# Altium schematic sheet Log and Anti-Log Converters 2.SchDoc
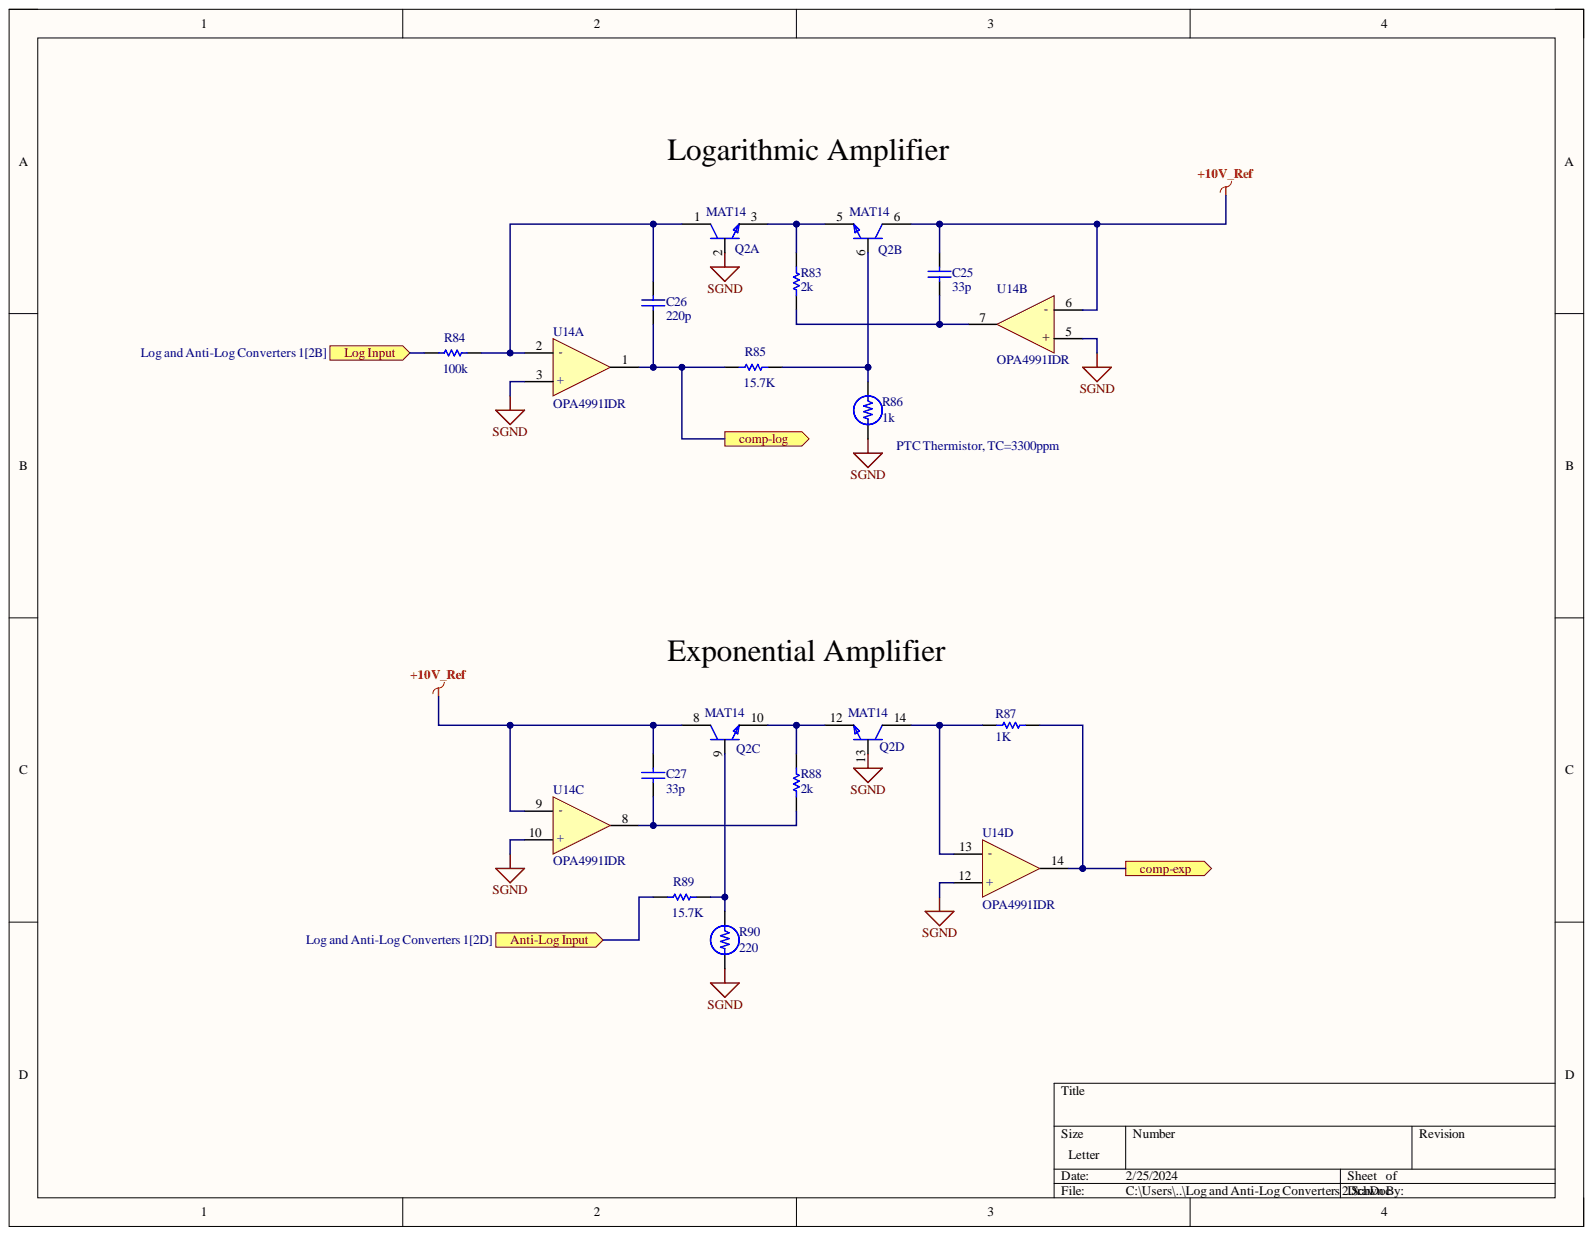
[diagram p0: Log and Anti-Log Converters 2.SchDoc]

## Parts
C25  value 33p  (hidden comment: Capacitor)
C26  value 220p  (hidden comment: Capacitor)
C27  value 33p  (hidden comment: Capacitor)
Q2  MAT14  [FP-R-14-IPC_B]  (parts A,B,C,D)
R83  value 2k  (hidden comment: Resistor)
R84  value 100k  (hidden comment: Resistor)
R85  value 15.7K  (hidden comment: Resistor)
R86  1k
R87  value 1K  (hidden comment: Resistor)
R88  value 2k  (hidden comment: Resistor)
R89  value 15.7K  (hidden comment: Resistor)
R90  220
U14  OPA4991IDR  [FP-D0014A-IPC_B]  (parts A,B,C,D)

## Ports
Anti-Log Input, comp-exp, comp-log, Log Input

## Nets
+10V_Ref: C25.2 C27.2 Q2.6 Q2.8 U14.6(-) U14.9(-)
SGND: Q2.2 Q2.13 R86.1 R90.1 U14.3(+) U14.5(+) U14.10(+) U14.12(+)
Anti-Log Input: R89.2 ; port Anti-Log Input
comp-exp: R87.2 U14.14 ; port comp-exp
comp-log: C26.1 R85.2 U14.1 ; port comp-log
Log Input: R84.2 ; port Log Input
NetC25_1: C25.1 R83.1 U14.7
NetC26_2: C26.2 Q2.1 R84.1 U14.2(-)
NetC27_1: C27.1 R88.1 U14.8
NetQ2_3: Q2.3 Q2.5 R83.2
NetQ2_6: Q2.6 R85.1 R86.2
NetQ2_9: Q2.9 R89.1 R90.2
NetQ2_10: Q2.10 Q2.12 R88.2
NetQ2_14: Q2.14 R87.1 U14.13(-)

## Library data of the parts
C25-C27 = Capacitor: SimulationGenericComponent: True; Component Kind: Standard; Description: Capacitor; Library Name: Simulation Generic Components.IntLib; Simulation: C_Capacitor_Default
Q2 = MAT14ARZ: TRANS 4NPN 40V 0.03A 14SO; Collector Emitter Saturation Voltage: 60mV; Frequency: 300MHz; Max Collector Current: 30mA; Max Frequency: 1kHz; Number of Elements: 4; Polarity: NPN; Case/Package: SOIC; Collector Base Voltage (VCBO): 40V; Collector Emitter Breakdown Voltage: 40V; Collector Emitter Voltage (VCEO): 40V; Collector-emitter Voltage-Max: 60mV; Component Kind: Standard; Height: 1.5mm; Lead Free: Contains Lead; Length: 8.75mm; Max Operating Temperature: 85°C; Min Operating Temperature: -40°C; Mount: Surface Mount; Number of Pins: 14; Package Quantity: 56; Packaging: Rail/Tube; Radiation Hardening: No; REACH SVHC: No SVHC; RoHS Compliant: Yes; Series: MAT14; Transition Frequency: 300MHz; Width: 4mm; Library Name: DRC.SchLib
R83-R85 R87-R89 = Resistor: SimulationGenericComponent: True; TC1: 0; Component Kind: Standard; Description: Resistor; Library Name: Simulation Generic Components.IntLib; Simulation: R_Resistor_Default; TC2: 0
R86 R90 = PTC Thermistor: Component Kind: Standard; Library Name: DRC.SchLib
U14 = OPA4991IDR: 40-V, DUAL 4.5MHZ, RAIL-TO-RAIL; Component Kind: Standard; Library Name: DRC.SchLib
